# Altium schematic sheet LED Matrix.SchDoc("LED Matrix")
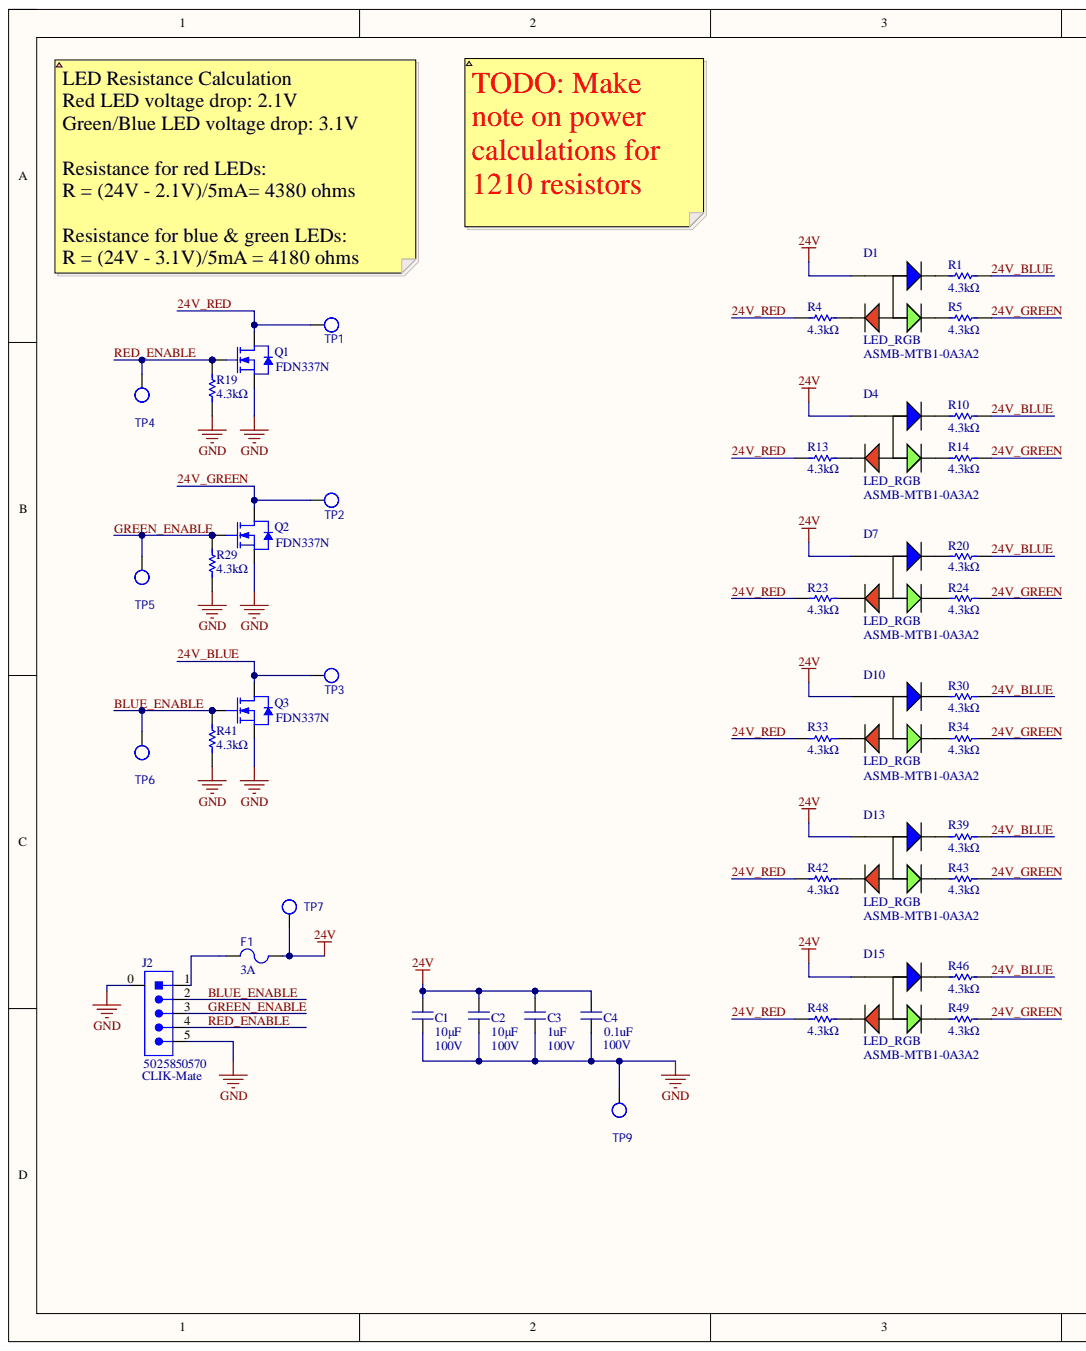
[diagram p0: LED Matrix.SchDoc, left]
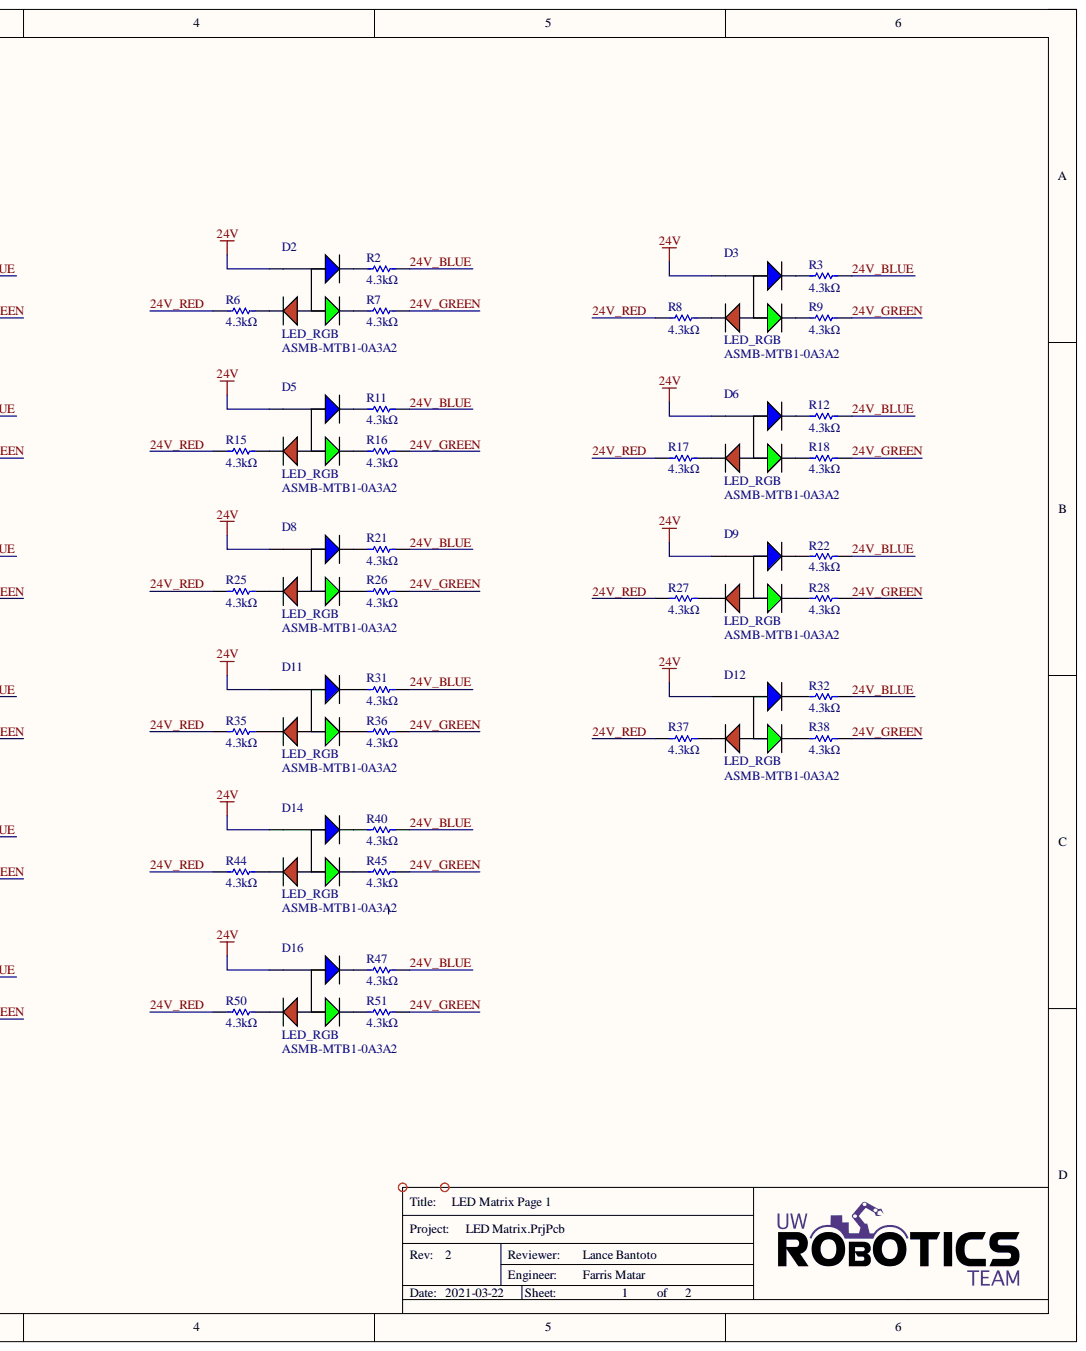
[diagram p1: LED Matrix.SchDoc, right]

## Parts
C1  value 10μF  Voltage Rating: 100V  [CAP1210]  (hidden comment: CAP_10uF_100V_1210)
C2  value 10μF  Voltage Rating: 100V  [CAP1210]  (hidden comment: CAP_10uF_100V_1210)
C3  Capacitance: 1uF  Voltage Rating: 100V  [CAPC3216X190X50NL20T25]  (hidden comment: CAP_1uF_100V_1206)
C4  Capacitance: 0.1uF  Voltage Rating (DC): 100V  [CAPC3216X180N]  (hidden comment: CAP_0.1uF_100V_1206)
D1  LED_RGB  Manufacturer Part Number 1: ASMB-MTB1-0A3A2  [LED_RGB]
D2  LED_RGB  Manufacturer Part Number 1: ASMB-MTB1-0A3A2  [LED_RGB]
D3  LED_RGB  Manufacturer Part Number 1: ASMB-MTB1-0A3A2  [LED_RGB]
D4  LED_RGB  Manufacturer Part Number 1: ASMB-MTB1-0A3A2  [LED_RGB]
D5  LED_RGB  Manufacturer Part Number 1: ASMB-MTB1-0A3A2  [LED_RGB]
D6  LED_RGB  Manufacturer Part Number 1: ASMB-MTB1-0A3A2  [LED_RGB]
D7  LED_RGB  Manufacturer Part Number 1: ASMB-MTB1-0A3A2  [LED_RGB]
D8  LED_RGB  Manufacturer Part Number 1: ASMB-MTB1-0A3A2  [LED_RGB]
D9  LED_RGB  Manufacturer Part Number 1: ASMB-MTB1-0A3A2  [LED_RGB]
D10  LED_RGB  Manufacturer Part Number 1: ASMB-MTB1-0A3A2  [LED_RGB]
D11  LED_RGB  Manufacturer Part Number 1: ASMB-MTB1-0A3A2  [LED_RGB]
D12  LED_RGB  Manufacturer Part Number 1: ASMB-MTB1-0A3A2  [LED_RGB]
D13  LED_RGB  Manufacturer Part Number 1: ASMB-MTB1-0A3A2  [LED_RGB]
D14  LED_RGB  Manufacturer Part Number 1: ASMB-MTB1-0A3A2  [LED_RGB]
D15  LED_RGB  Manufacturer Part Number 1: ASMB-MTB1-0A3A2  [LED_RGB]
D16  LED_RGB  Manufacturer Part Number 1: ASMB-MTB1-0A3A2  [LED_RGB]
F1  Current Rating: 3A  [FUSE_1206]  (hidden comment: FUSE_3A_1206)
J2  Family: CLIK-Mate  Manufacturer Part Number 1: 5025850570  [MOLEX-5025850570]  (hidden comment: CON_5M_SM_RA)
MH1  MOUNTING_HOLES  [MOUNTING_HOLE]  (value not drawn)
MH2  MOUNTING_HOLES  [MOUNTING_HOLE]  (value not drawn)
Q1  Manufacturer Part Number 1: FDN337N  [NMOS_SSOT-3]  (hidden comment: NMOS_30V_2.2A)
Q2  Manufacturer Part Number 1: FDN337N  [NMOS_SSOT-3]  (hidden comment: NMOS_30V_2.2A)
Q3  Manufacturer Part Number 1: FDN337N  [NMOS_SSOT-3]  (hidden comment: NMOS_30V_2.2A)
R1  Resistance: 4.3kΩ  [RESC1210]  (hidden comment: RES_4.3kΩ_1210_5%)
R2  Resistance: 4.3kΩ  [RESC1210]  (hidden comment: RES_4.3kΩ_1210_5%)
R3  Resistance: 4.3kΩ  [RESC1210]  (hidden comment: RES_4.3kΩ_1210_5%)
R4  Resistance: 4.3kΩ  [RESC1210]  (hidden comment: RES_4.3kΩ_1210_5%)
R5  Resistance: 4.3kΩ  [RESC1210]  (hidden comment: RES_4.3kΩ_1210_5%)
R6  Resistance: 4.3kΩ  [RESC1210]  (hidden comment: RES_4.3kΩ_1210_5%)
R7  Resistance: 4.3kΩ  [RESC1210]  (hidden comment: RES_4.3kΩ_1210_5%)
R8  Resistance: 4.3kΩ  [RESC1210]  (hidden comment: RES_4.3kΩ_1210_5%)
R9  Resistance: 4.3kΩ  [RESC1210]  (hidden comment: RES_4.3kΩ_1210_5%)
R10  Resistance: 4.3kΩ  [RESC1210]  (hidden comment: RES_4.3kΩ_1210_5%)
R11  Resistance: 4.3kΩ  [RESC1210]  (hidden comment: RES_4.3kΩ_1210_5%)
R12  Resistance: 4.3kΩ  [RESC1210]  (hidden comment: RES_4.3kΩ_1210_5%)
R13  Resistance: 4.3kΩ  [RESC1210]  (hidden comment: RES_4.3kΩ_1210_5%)
R14  Resistance: 4.3kΩ  [RESC1210]  (hidden comment: RES_4.3kΩ_1210_5%)
R15  Resistance: 4.3kΩ  [RESC1210]  (hidden comment: RES_4.3kΩ_1210_5%)
R16  Resistance: 4.3kΩ  [RESC1210]  (hidden comment: RES_4.3kΩ_1210_5%)
R17  Resistance: 4.3kΩ  [RESC1210]  (hidden comment: RES_4.3kΩ_1210_5%)
R18  Resistance: 4.3kΩ  [RESC1210]  (hidden comment: RES_4.3kΩ_1210_5%)
R19  Resistance: 4.3kΩ  [RESC1210]  (hidden comment: RES_4.3kΩ_1210_5%)
R20  Resistance: 4.3kΩ  [RESC1210]  (hidden comment: RES_4.3kΩ_1210_5%)
R21  Resistance: 4.3kΩ  [RESC1210]  (hidden comment: RES_4.3kΩ_1210_5%)
R22  Resistance: 4.3kΩ  [RESC1210]  (hidden comment: RES_4.3kΩ_1210_5%)
R23  Resistance: 4.3kΩ  [RESC1210]  (hidden comment: RES_4.3kΩ_1210_5%)
R24  Resistance: 4.3kΩ  [RESC1210]  (hidden comment: RES_4.3kΩ_1210_5%)
R25  Resistance: 4.3kΩ  [RESC1210]  (hidden comment: RES_4.3kΩ_1210_5%)
R26  Resistance: 4.3kΩ  [RESC1210]  (hidden comment: RES_4.3kΩ_1210_5%)
R27  Resistance: 4.3kΩ  [RESC1210]  (hidden comment: RES_4.3kΩ_1210_5%)
R28  Resistance: 4.3kΩ  [RESC1210]  (hidden comment: RES_4.3kΩ_1210_5%)
R29  Resistance: 4.3kΩ  [RESC1210]  (hidden comment: RES_4.3kΩ_1210_5%)
R30  Resistance: 4.3kΩ  [RESC1210]  (hidden comment: RES_4.3kΩ_1210_5%)
R31  Resistance: 4.3kΩ  [RESC1210]  (hidden comment: RES_4.3kΩ_1210_5%)
R32  Resistance: 4.3kΩ  [RESC1210]  (hidden comment: RES_4.3kΩ_1210_5%)
R33  Resistance: 4.3kΩ  [RESC1210]  (hidden comment: RES_4.3kΩ_1210_5%)
R34  Resistance: 4.3kΩ  [RESC1210]  (hidden comment: RES_4.3kΩ_1210_5%)
R35  Resistance: 4.3kΩ  [RESC1210]  (hidden comment: RES_4.3kΩ_1210_5%)
R36  Resistance: 4.3kΩ  [RESC1210]  (hidden comment: RES_4.3kΩ_1210_5%)
R37  Resistance: 4.3kΩ  [RESC1210]  (hidden comment: RES_4.3kΩ_1210_5%)
R38  Resistance: 4.3kΩ  [RESC1210]  (hidden comment: RES_4.3kΩ_1210_5%)
R39  Resistance: 4.3kΩ  [RESC1210]  (hidden comment: RES_4.3kΩ_1210_5%)
R40  Resistance: 4.3kΩ  [RESC1210]  (hidden comment: RES_4.3kΩ_1210_5%)
R41  Resistance: 4.3kΩ  [RESC1210]  (hidden comment: RES_4.3kΩ_1210_5%)
R42  Resistance: 4.3kΩ  [RESC1210]  (hidden comment: RES_4.3kΩ_1210_5%)
R43  Resistance: 4.3kΩ  [RESC1210]  (hidden comment: RES_4.3kΩ_1210_5%)
R44  Resistance: 4.3kΩ  [RESC1210]  (hidden comment: RES_4.3kΩ_1210_5%)
R45  Resistance: 4.3kΩ  [RESC1210]  (hidden comment: RES_4.3kΩ_1210_5%)
R46  Resistance: 4.3kΩ  [RESC1210]  (hidden comment: RES_4.3kΩ_1210_5%)
R47  Resistance: 4.3kΩ  [RESC1210]  (hidden comment: RES_4.3kΩ_1210_5%)
R48  Resistance: 4.3kΩ  [RESC1210]  (hidden comment: RES_4.3kΩ_1210_5%)
R49  Resistance: 4.3kΩ  [RESC1210]  (hidden comment: RES_4.3kΩ_1210_5%)
R50  Resistance: 4.3kΩ  [RESC1210]  (hidden comment: RES_4.3kΩ_1210_5%)
R51  Resistance: 4.3kΩ  [RESC1210]  (hidden comment: RES_4.3kΩ_1210_5%)
TP1  TESTPOINT_LOOP  [TESTPOINT_LOOP]  (value not drawn)
TP2  TESTPOINT_LOOP  [TESTPOINT_LOOP]  (value not drawn)
TP3  TESTPOINT_LOOP  [TESTPOINT_LOOP]  (value not drawn)
TP4  TESTPOINT_LOOP  [TESTPOINT_LOOP]  (value not drawn)
TP5  TESTPOINT_LOOP  [TESTPOINT_LOOP]  (value not drawn)
TP6  TESTPOINT_LOOP  [TESTPOINT_LOOP]  (value not drawn)
TP7  TESTPOINT_LOOP  [TESTPOINT_LOOP]  (value not drawn)
TP9  TESTPOINT_LOOP  [TESTPOINT_LOOP]  (value not drawn)

## Nets
24V: C1.2 C2.2 C3.1 C4.2 D1.4 D2.4 D3.4 D4.4 D5.4 D6.4 D7.4 D8.4 D9.4 D10.4 D11.4 D12.4 D13.4 D14.4 D15.4 D16.4 F1.1 TP7.1
GND: C1.1 C2.1 C3.2 C4.1 J2.0 J2.5 Q1.2 Q2.2 Q3.2 R19.1 R29.1 R41.1 TP9.1
24V_BLUE: Q3.3 R1.2 R2.2 R3.2 R10.2 R11.2 R12.2 R20.1 R21.1 R22.1 R30.2 R31.2 R32.2 R39.1 R40.2 R46.2 R47.2 TP3.1
24V_GREEN: Q2.3 R5.2 R7.2 R9.2 R14.2 R16.2 R18.2 R24.2 R26.2 R28.2 R34.2 R36.2 R38.2 R43.2 R45.2 R49.2 R51.2 TP2.1
24V_RED: Q1.3 R4.1 R6.1 R8.1 R13.1 R15.1 R17.1 R23.2 R25.1 R27.1 R33.1 R35.1 R37.1 R42.1 R44.1 R48.1 R50.1 TP1.1
BLUE_ENABLE: J2.2 Q3.1 R41.2 TP6.1
GREEN_ENABLE: J2.3 Q2.1 R29.2 TP5.1
RED_ENABLE: J2.4 Q1.1 R19.2 TP4.1
NetD1_1: D1.1 R4.2
NetD1_2: D1.2 R5.1
NetD1_3: D1.3 R1.1
NetD2_1: D2.1 R6.2
NetD2_2: D2.2 R7.1
NetD2_3: D2.3 R2.1
NetD3_1: D3.1 R8.2
NetD3_2: D3.2 R9.1
NetD3_3: D3.3 R3.1
NetD4_1: D4.1 R13.2
NetD4_2: D4.2 R14.1
NetD4_3: D4.3 R10.1
NetD5_1: D5.1 R15.2
NetD5_2: D5.2 R16.1
NetD5_3: D5.3 R11.1
NetD6_1: D6.1 R17.2
NetD6_2: D6.2 R18.1
NetD6_3: D6.3 R12.1
NetD7_1: D7.1 R23.1
NetD7_2: D7.2 R24.1
NetD7_3: D7.3 R20.2
NetD8_1: D8.1 R25.2
NetD8_2: D8.2 R26.1
NetD8_3: D8.3 R21.2
NetD9_1: D9.1 R27.2
NetD9_2: D9.2 R28.1
NetD9_3: D9.3 R22.2
NetD10_1: D10.1 R33.2
NetD10_2: D10.2 R34.1
NetD10_3: D10.3 R30.1
NetD11_1: D11.1 R35.2
NetD11_2: D11.2 R36.1
NetD11_3: D11.3 R31.1
NetD12_1: D12.1 R37.2
NetD12_2: D12.2 R38.1
NetD12_3: D12.3 R32.1
NetD13_1: D13.1 R42.2
NetD13_2: D13.2 R43.1
NetD13_3: D13.3 R39.2
NetD14_1: D14.1 R44.2
NetD14_2: D14.2 R45.1
NetD14_3: D14.3 R40.1
NetD15_1: D15.1 R48.2
NetD15_2: D15.2 R49.1
NetD15_3: D15.3 R46.1
NetD16_1: D16.1 R50.2
NetD16_2: D16.2 R51.1
NetD16_3: D16.3 R47.1
NetF1_2: F1.2 J2.1

## Library data of the parts
C1 C2 = CAP_10uF_100V_1210: CAP CER 10uF 100V X7S 10% 1210; Manufacturer 1: Murata; Manufacturer Part Number 1: GRM32EC72A106KE05L; Package/Footprint: 1210; Component Kind: Standard; Dielectric: X7S; Mounting Type: SMD; Supplier 1: Digi-Key; Supplier Part Number 1: 490-16266-1-ND; Tolerance: 10%; Library Name: UWRT_Schematic_Library_2020.SchLib
C3 = CAP_1uF_100V_1206: CAP CER 1UF 100V X7R 1206; Manufacturer: Murata; Manufacturer Part Number: GCJ31CR72A105KA01L; Package/Footprint: 1206; Component Kind: Standard; Mounting Type: SMD; Supplier 1: Digi-Key; Supplier Part Number 1: 490-16468-1-ND; Library Name: UWRT_Schematic_Library_2020.SchLib
C4 = CAP_0.1uF_100V_1206: CAP CER 0.1UF 100V C0G/NP0 1206; Manufacturer: Murata; Manufacturer Part Number: GRM31C5C2A104JA01L; Dielectric: C0G; Tolerance: 5%; Category: Ceramic Capacitors; Component Kind: Standard; ComponentLink1Description: https://psearch.en.murata.com:443/capacitor/product/GRM31C5C2A104JA01%23.pdf?src-supplier=IHS+Markit; ComponentLink1URL: https://psearch.en.murata.com:443/capacitor/product/GRM31C5C2A104JA01%23.pdf?src-supplier=IHS+Markit; ComponentLink2Description: https://search.murata.co.jp/Ceramy/image/img/A01X/G101/ENG/GRM31C5C2A104JA01-01.pdf?src-supplier=Digi-Key; ComponentLink2URL: https://search.murata.co.jp/Ceramy/image/img/A01X/G101/ENG/GRM31C5C2A104JA01-01.pdf?src-supplier=Digi-Key; ComponentLink3Description: http://search.murata.co.jp/Ceramy/image/img/A01X/G101/ENG/GRM31C5C2A104JA01-01.pdf?src-supplier=Digi-Key; ComponentLink3URL: http://search.murata.co.jp/Ceramy/image/img/A01X/G101/ENG/GRM31C5C2A104JA01-01.pdf?src-supplier=Digi-Key; Length: 3.2mm; Pricing 1: 1=0.69000, 10=0.48900, 50=0.36940, 100=0.32180, 500=0.23838, 1000=0.20261 (USD); RoHS: No; Stock 1: 18337; Supplier 1: Digi-Key; Supplier Part Number 1: 490-16315-1-ND; Thickness: 1.6mm; Width: 1.6mm; Library Name: UWRT_Schematic_Library_2020.SchLib
D1-D16 = LED_RGB: LED RGB DIFFUSED 4PLCC SMD; Manufacturer 1: Broadcom; Color: Blue,Green,Red; Dominant Wavelength: 470nm; Forward Voltage: 3.1V; Lens Color: White; Lens Style: Round; Luminous Intensity: 350mcd; Package/Footprint: 4-PLCC; Test Current: 20mA; Viewing Angle: 115°; Case/Package: PLCC; Component Kind: Standard; Height: 1.9mm; Length: 3.2mm; Lens Transparency: Diffused; Mounting Type: SMD; Number of Pins: 4; Supplier 1: Digi-Key; Supplier Part Number 1: 516-3280-1-ND; Width: 2.8mm; Library Name: UWRT_Schematic_Library_2020.SchLib
F1 = FUSE_3A_1206: FUSE BOARD MNT 3A 32VAC/VDC 1206; Manufacturer 1: Littelfuse; Manufacturer Part Number 1: 0466003.NR; Package/Footprint: 1206; Response Time: Fast Blow; Working Voltage: 32V; Component Kind: Standard; Mounting Type: SMD; Supplier 1: Digi-Key; Supplier Part Number 1: F1458CT-ND; Library Name: UWRT_Schematic_Library_2020.SchLib
J2 = CON_5M_SM_RA: CONN HEADER SMD RIGHT ANGLE 1.5MM PITCH; Manufacturer 1: Molex; Current Rating: 2A; Component Kind: Standard; Mounting Type: SMD; Orientation: Right Angle; Package/Footprint: SMD; Supplier 1: Digi-Key; Supplier Part Number 1: WM14469CT-ND; Library Name: UWRT_Schematic_Library_2020.SchLib
MH1 MH2 = MOUNTING_HOLES: 6cm x 6cm; Component Kind: Standard; Library Name: UWRT_Schematic_Library_2020.SchLib
Q1-Q3 = NMOS_30V_2.2A: MOSFET N-CH 30V 2.2A SUPERSOT3; Manufacturer 1: ON Semiconductor / Fairchild; Drain to Source Resistance: 65mR; Element Configuration: Single; Fall Time: 4ns; Gate to Source Voltage (Vgs): 8V; Input Capacitance: 300pF; Max Power Dissipation: 500mW; Nominal Vgs: 700mV; Number of Elements: 1; Package/Footprint: SuperSOT-3; Rise Time: 10ns; Turn-Off Delay Time: 17ns; Category: MOSFETs; Component Kind: Standard; Continuous Drain Current (ID): 2.2A; Current Rating: 2.2A; Drain to Source Breakdown Voltage: 30V; Drain to Source Voltage (Vdss): 30V; Dual Supply Voltage: 30V; Max Operating Temperature: 150°C; Mounting Type: SMD; On-State Resistance: 65mR; Power Dissipation: 500mW; Rds On Max: 65mR; Supplier 1: Digi-Key; Supplier Part Number 1: FDN337NCT-ND; Threshold Voltage: 700mV; Turn-On Delay Time: 4ns; Voltage Rating (DC): 30V; Library Name: UWRT_Schematic_Library_2020.SchLib
R1-R51 = RES_4.3kΩ_1210_5%: RES SMD 4.3K OHM 5% 1/2W 1210; Manufacturer 1: Panasonic; Manufacturer Part Number 1: ERJ-14YJ432U; Power Rating: 500mW; Voltage Rating: 200V; Component Kind: Standard; Mount: Surface Mount; Package: 1210; Supplier 1: Digi-Key; Supplier Part Number 1: P4.3KVCT-ND; Tolerance: 5%; Library Name: UWRT_Schematic_Library_2020.SchLib
TP1-TP7 TP9 = TESTPOINT_LOOP: PC TEST POINT MINIATURE WHITE; Manufacturer 1: Keystone Electronics; Manufacturer Part Number 1: 5002; Package/Footprint: TH; Component Kind: Standard; Mounting Type: TH; Supplier 1: Digi-Key; Supplier Part Number 1: 36-5002-ND; Library Name: UWRT_Schematic_Library_2020.SchLib
